# Altium schematic sheet 19-100M_Ethernet.SchDoc
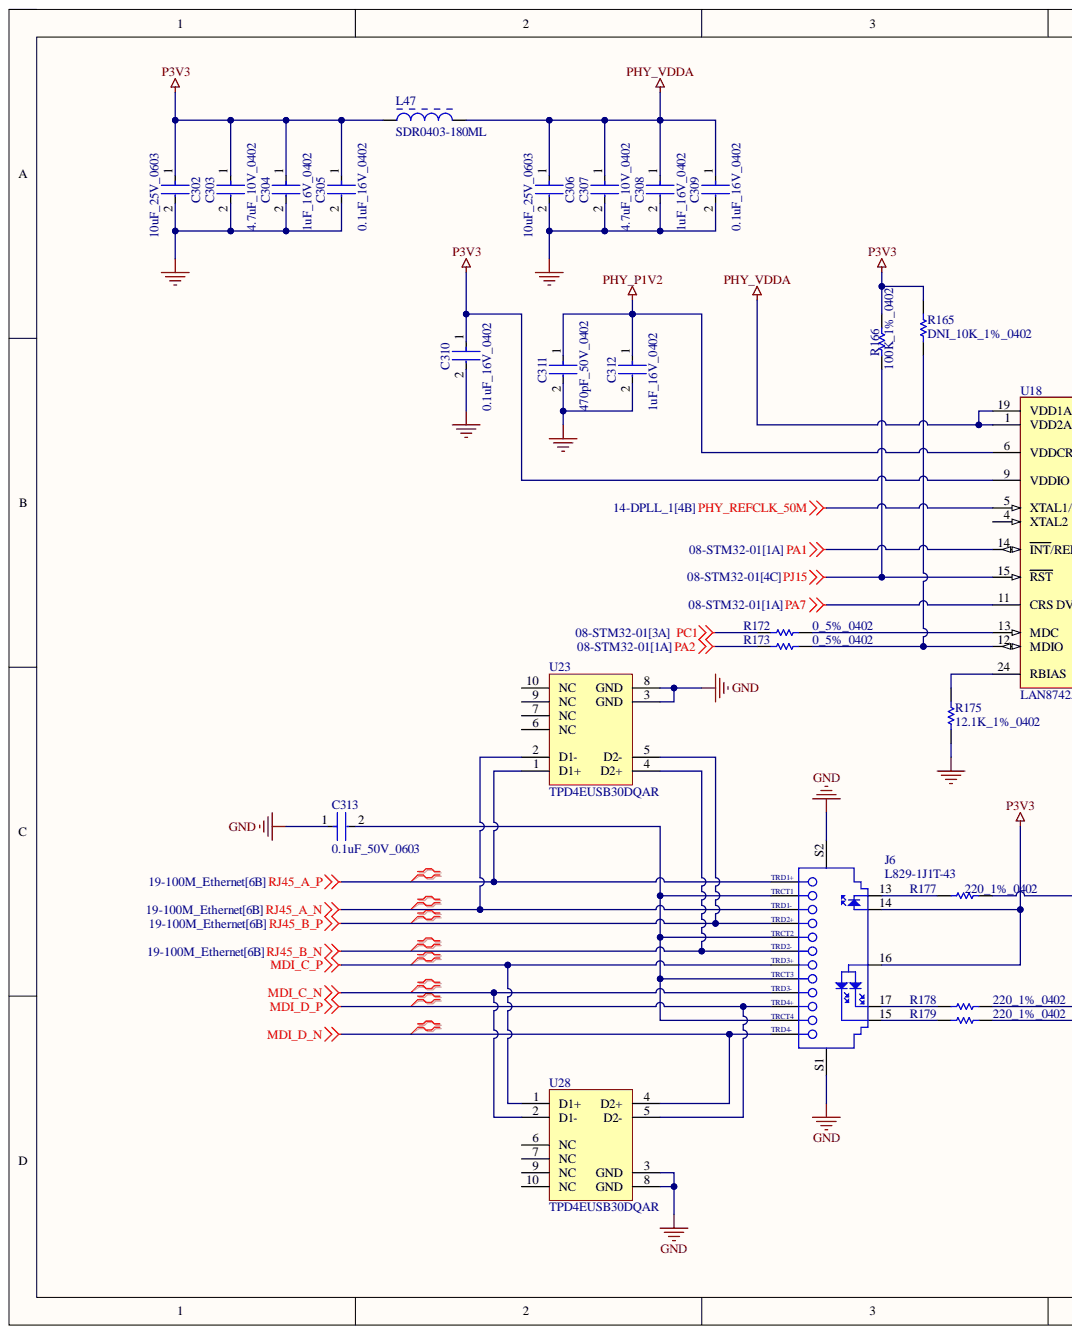
[diagram p0: 19-100M_Ethernet.SchDoc, left]
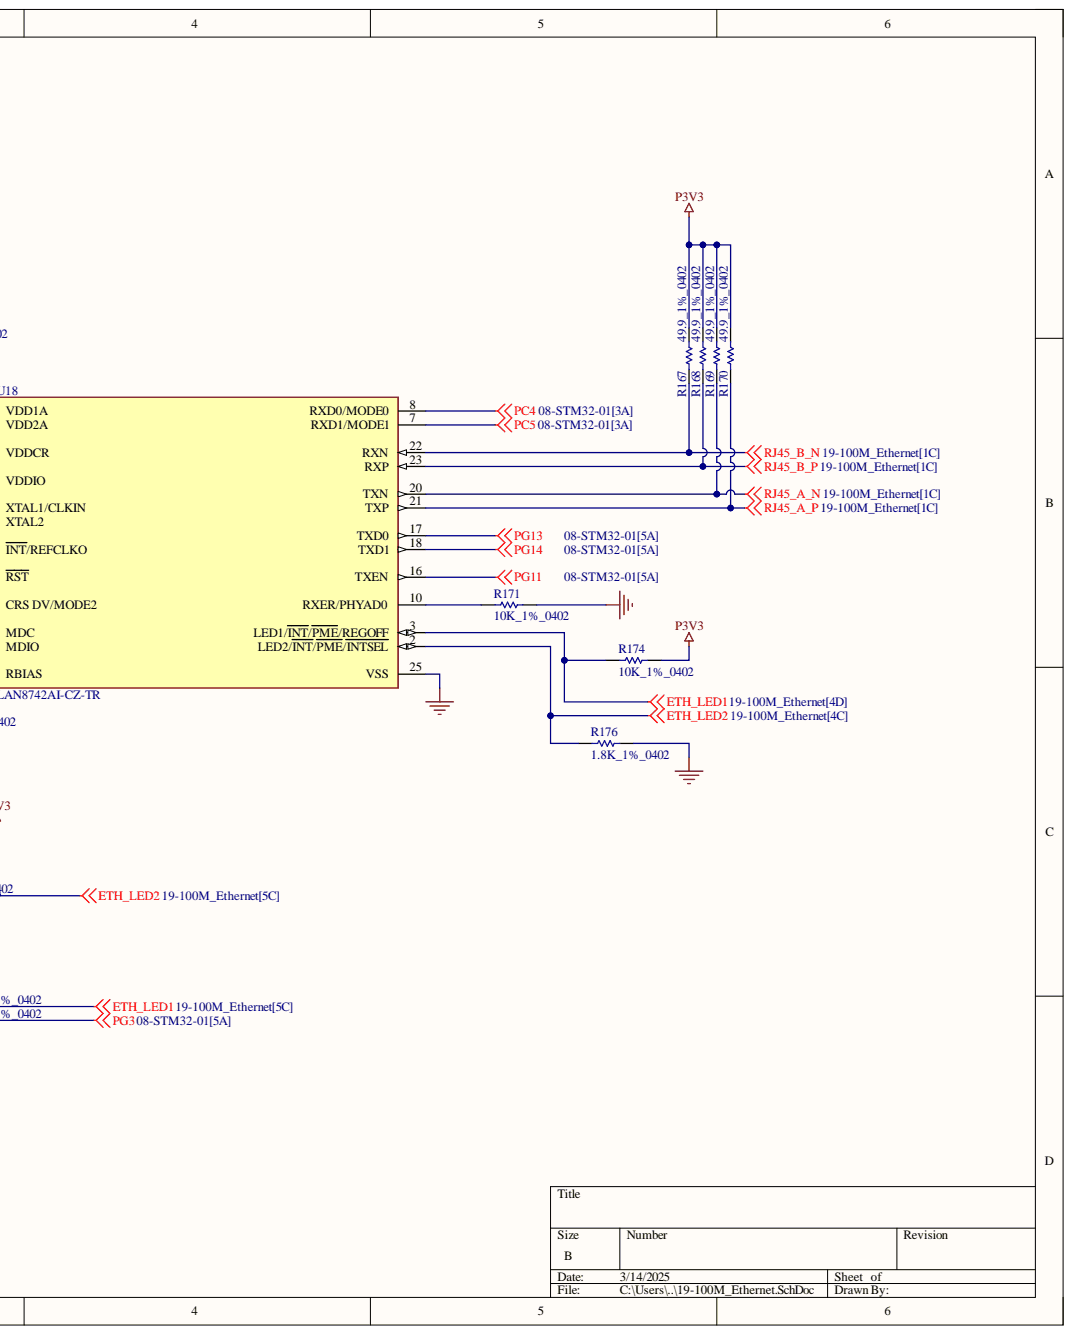
[diagram p1: 19-100M_Ethernet.SchDoc, right]

## Parts
C302  10uF_25V_0603  [FP-CL10-IPC_C]
C303  4.7uF_10V_0402  [FP-C1005-050-0_15-MFG]
C304  1uF_16V_0402  [FP-0402-L_1_0_0_05-W_0_5-IPC_A]
C305  0.1uF_16V_0402  [FP-C0402-BB-MFG]
C306  10uF_25V_0603  [FP-CL10-IPC_C]
C307  4.7uF_10V_0402  [FP-C1005-050-0_15-MFG]
C308  1uF_16V_0402  [FP-0402-L_1_0_0_05-W_0_5-IPC_A]
C309  0.1uF_16V_0402  [FP-C0402-BB-MFG]
C310  0.1uF_16V_0402  [FP-C0402-BB-MFG]
C311  470pF_50V_0402  [FP-GRM155-0_05-IPC_A]
C312  1uF_16V_0402  [FP-0402-L_1_0_0_05-W_0_5-IPC_A]
C313  0.1uF_50V_0603  [FP-C0603-CJ-MFG]
J6  L829-1J1T-43  [BELS-L829-1J1T-43_V]
L47  SDR0403-180ML  [BOUR-SDR0403-2_V]
R165  DNI_10K_1%_0402  [RESC1005X40X25NL10T10]  (no BOM)
R166  100K_1%_0402  [RESC1005X40X25ML05T10]
R167  49.9_1%_0402  [RESC1005X40X25NL10T10]
R168  49.9_1%_0402  [RESC1005X40X25NL10T10]
R169  49.9_1%_0402  [RESC1005X40X25NL10T10]
R170  49.9_1%_0402  [RESC1005X40X25NL10T10]
R171  10K_1%_0402  [RESC1005X40X25NL10T10]
R172  0_5%_0402  [RESC1005X40X25NL05T10]
R173  0_5%_0402  [RESC1005X40X25NL05T10]
R174  10K_1%_0402  [RESC1005X40X25NL10T10]
R175  12.1K_1%_0402  [RESC1005X40X25ML05T10]
R176  1.8K_1%_0402  [RESC1005X40X25LL05T05]
R177  220_1%_0402  [RESC1005X40X25LL10T10]
R178  220_1%_0402  [RESC1005X40X25LL10T10]
R179  220_1%_0402  [RESC1005X40X25LL10T10]
U18  LAN8742AI-CZ-TR  [FP-C04-143-MFG]
U23  TPD4EUSB30DQAR  [DQA0010A_V]
U28  TPD4EUSB30DQAR  [DQA0010A_V]

## Nets
ETH_LED1: R174.2 R178.2 U18.3(LED1/INT/PME/REGOFF)
ETH_LED2: R176.1 R177.2 U18.2(LED2/INT/PME/INTSEL)
GND: C302.2 C303.2 C304.2 C305.2 C306.2 C307.2 C308.2 C309.2 C310.2 C311.2 C312.2 C313.1 J6.S1 J6.S2 R171.1 R175.1 R176.2 U18.25(VSS) U23.3(GND) U23.8(GND) U28.3(GND) U28.8(GND)
MDI_C_N: J6.9 U28.2(D1-)
MDI_C_P: J6.7 U28.1(D1+)
MDI_D_N: J6.12 U28.4(D2+)
MDI_D_P: J6.10 U28.5(D2-)
P3V3: C302.1 C303.1 C304.1 C305.1 C310.1 J6.14 J6.16 L47.1 R165.1 R166.2 R167.1 R168.1 R169.1 R170.1 R174.1 U18.9(VDDIO)
PA1: U18.14(INT/REFCLKO)
PA2: R173.1
PA7: U18.11(CRS_DV/MODE2)
PC1: R172.1
PC4: U18.8(RXD0/MODE0)
PC5: U18.7(RXD1/MODE1)
PG3: R179.2
PG11: U18.16(TXEN)
PG13: U18.17(TXD0)
PG14: U18.18(TXD1)
PHY_P1V2: C311.1 C312.1 U18.6(VDDCR)
PHY_REFCLK_50M: U18.5(XTAL1/CLKIN)
PHY_VDDA: C306.1 C307.1 C308.1 C309.1 L47.2 U18.1(VDD2A) U18.19(VDD1A)
PJ15: R166.1 U18.15(RST)
RJ45_A_N: J6.3 R169.2 U18.20(TXN) U23.2(D1-)
RJ45_A_P: J6.1 R170.2 U18.21(TXP) U23.1(D1+)
RJ45_B_N: J6.6 R167.2 U18.22(RXN) U23.4(D2+)
RJ45_B_P: J6.4 R168.2 U18.23(RXP) U23.5(D2-)
NetC313_2: C313.2 J6.2 J6.5 J6.8 J6.11
NetJ6_13: J6.13 R177.1
NetJ6_15: J6.15 R179.1
NetJ6_17: J6.17 R178.1
NetR165_2: R165.2 R173.2 U18.12(MDIO)
NetR171_2: R171.2 U18.10(RXER/PHYAD0)
NetR172_2: R172.2 U18.13(MDC)
NetR175_2: R175.2 U18.24(RBIAS)

Not connected: U18 4(XTAL2); U23 6(NC) 7(NC) 9(NC) 10(NC); U28 6(NC) 7(NC) 9(NC) 10(NC)

## Library data of the parts
C302 C306 = CMP-13271-004224-1: Cap Ceramic 10uF 25V X5R ±20% Pad SMD 0603 +85°C T/R; Capacitance: 10uF; Case Code (Imperial): 0603; Case Code (Metric): 1608; Case/Package: 0603; Component Kind: Standard; Contact Plating: Tin; Dielectric: X5R; Lead Free: Lead Free; Length: 0.063inch; Library Name: Altium Content Vault; Max Operating Temperature: 85°C; Min Operating Temperature: -55°C; Mount: Surface Mount; Package Quantity: 1; Packaging: Tape and Reel; Termination: Wraparound; Thickness: 0.035inch; Tolerance: 20%; Voltage Rating: 25V; Width: 0.031inch
C303 C307 = CMP-2000-05997-2: CAP CER 4.7UF 10V X5R 0402; Dielectric Material: Ceramic; Tolerance: 10%; Capacitance: 4.7uF; Case Code (Imperial): 0402; Case Code (Metric): 1005; Case/Package: 0402; Component Kind: Standard; Dielectric: X5R; Lead Free: Lead Free; Length: 0.039inch; Library Name: Altium Content Vault; Max Operating Temperature: 85°C; Min Operating Temperature: -55°C; Mount: Surface Mount; Package Quantity: 10000; Packaging: Cut Tape; REACH SVHC: No SVHC; RoHS Compliant: Yes; Series: C; Thickness: 0.022inch; Voltage Rating: 10V; Voltage Rating (DC): 10V; Width: 0.02inch
C304 C308 C312 = CMP-14477-000104-2: None; Capacitance: 1uF; Dielectric: X5R; Tolerance: 10%; Voltage Rating: 16V; Case Code (Imperial): 0402; Case Code (Metric): 1005; Case/Package: 0402; Component Kind: Standard; Construction: Flat; Depth: 0.5mm; Halogen Free: Halogen Free; Height: 0.5mm; Length: 1mm; Library Name: Altium Content Vault; Max Operating Temperature: 85°C; Min Operating Temperature: -55°C; Mount: Surface Mount; Number of Pins: 2; Package Quantity: 1; Packaging: Tape and Reel; Radiation Hardening: No; RoHS Compliant: Yes; Series: M; Termination: SMD/SMT; Thickness: 0.022inch; Voltage Rating (DC): 16V; Width: 0.02inch
C305 C309 C310 = CMP-1034-02068-3: CAP CER 0.1UF 16V 5% X7R 0402; Manufacturer: Kemet; Manufacturer Part Number: C0402C104J4RACTU; Capacitance: 0.10 μF; Material: Ceramic; Temperature Coefficient: 15%; Tolerance: 0.05; Value: 0.10 μF; Automotive: No; Category: Cap; Component Kind: Standard; ComponentLink2Description: Datasheet; ComponentLink2URL: https://content.kemet.com/datasheets/KEM_C1002_X7R_SMD.pdf; Device Class L1: Passive Components; Device Class L2: Capacitors; Device Class L3: Ceramic Capacitors; Digikey Description: CAP CER 0.1UF 16V 5% X7R 0402; Height: 0.55mm; Ipc Land Pattern Name: CAPC10050X50; Lead Free: Yes; Library Name: Altium Content Vault; Mouser Description: CAP CER 0.1UF 16V X7R 0402; Octopart: https://octopart.com/c0402c104j4ractu-kemet-12573893; Package: C0402-BB; RoHS: TRUE; Temperature: -55°C to +125°C; Temperature Characteristic: X7R; Temperature Range High: +125°C; Temperature Range Low: -55°C; Voltage Rating: 16 V
C311 = CMP-2008-04369-2: Chip Multilayer Ceramic Capacitors for General Purpose, 0402, 470pF, C0G, 30ppm/°C, 5%, 50V; Insulation Resistance: 10GR; Capacitance: 470pF; Case Code (Imperial): 0402; Case Code (Metric): 1005; Case/Package: 0402; Component Kind: Standard; Depth: 0.5mm; Dielectric: C0G; Dielectric Material: Ceramic; Height: 0.5mm; Lead Free: Lead Free; Length: 1mm; Library Name: Altium Content Vault; Material: Ceramic; Max Operating Temperature: 125°C; Min Operating Temperature: -55°C; Mount: Surface Mount; Number of Pins: 2; Package Quantity: 1; Packaging: Tape and Reel; Radiation Hardening: No; REACH SVHC: No SVHC; Temperature Coefficient: 30ppm/°C; Termination: SMD/SMT; Thickness: 0.022inch; Tolerance: 5%; Voltage: 50V; Voltage Rating: 50V; Voltage Rating (DC): 50V; Width: 0.5mm
C313 = CMP-2006-03300-2: CAP CER 0.1UF 50V X7R 0603; Manufacturer: Kemet; Manufacturer Part Number: C0603X104K5RACTU; Capacitance: 100nF; Material: Ceramic; Temperature Coefficient: 15%; Tolerance: 10%; Value: 100nF; Automotive: No; Category: Cap; Component Kind: Standard; ComponentLink2Description: Datasheet; ComponentLink2URL: https://content.kemet.com/datasheets/KEM_C1013_X7R_FT-CAP_SMD.pdf; Device Class L1: Passive Components; Device Class L2: Capacitors; Device Class L3: Ceramic Capacitors; Digikey Description: CAP CER 0.1UF 50V X7R 0603; Height: 0.95mm; Ipc Land Pattern Name: CAPC16080X80; Lead Free: Yes; Library Name: Altium Content Vault; Mouser Description: Capacitor; Ceramic; Cap 0.1uF; Tol+10%; SMT; Flexible Termination; Vol-Rtg 50V; TR; Octopart: https://octopart.com/c0603x104k5ractu-kemet-9145062; Package: C0603-CJ; RoHS: TRUE; Temperature: -55°C to +125°C; Temperature Characteristic: X7R; Temperature Range High: +125°C; Temperature Range Low: -55°C; Voltage: 50V; Voltage Rating: 50V
J6 = CMP-1715-00001-1: Gigabit MagJack(R) Ultra Low Profile, 10/100/1000 Base-T, 19-Pin THD, RoHS, Tray; Case/Package: Metal; Component Kind: Standard; ComponentLink1Description: Manufacturer URL; ComponentLink1URL: http://belfuse.com/ethernet/; ComponentLink2Description: Datasheet; ComponentLink2URL: http://belfuse.com/pdfs/L829-1J1T-43.pdf; DatasheetVersion: Rev. G; Height: 11.3 mm; Length: 17.78 mm; Library Name: Altium Content Vault; Mount: Through Hole; Mounting Technology: Through Hole; Package Quantity: 60; PackageDescription: 19-Pin Through Hole Device, Body 23.94 x 17.58 mm, Pitch 2.03 mm; Packaging: Tray; PartNumber: L829-1J1T-43; Pins: 8; RoHSCompliant: True; Width: 24.13 mm
L47 = CMP-0904-00005-1: SDR0403 Series Power Inductor, 18 uF +/- 20 %, 0.34 Ohm, -40 to 125 degC, 2-Pin SMD, RoHS, Tape and Reel; Manufacturer: Bourns; I rms (A): 0.84 Max.; I sat (A): 1.3 Typ.; Inductance (uH): 18; Q: 25; RDC (Ohm): 0.34 Max.; SRF (MHz): 23 Min.; Test Frequency (MHz): 2.52; Tolerance (%): +/- 20; Component Kind: Standard; ComponentLink1Description: Manufacturer URL; ComponentLink1URL: http://www.bourns.com/; ComponentLink2Description: Datasheet; ComponentLink2URL: http://www.bourns.com/data/global/pdfs/sdr0403.pdf; DatasheetVersion: Feb-12; Library Name: Altium Content Vault; Mounting Technology: Surface Mount; PackageDescription: 3-Pin SMD, Dia 4.5 mm, Height 3.5 mm; PackageReference: SDR0403-2; Packing: Tape and Reel; PartNumber: SDR0403-180ML; RoHS: TRUE; Temperature Range: -40 to 125 degC
R165 R171 R174 = CMP-2002-04694-1: Manufacturer: Bourns; Part Number: CR0402-FX-1002GLF; Library Name: Altium Content Vault
  R171 R174: Component Kind: Standard
R166 = CMP-2002-07852-1: Manufacturer: Yageo; Part Number: RC0402FR-13100KL; Component Kind: Standard; Library Name: Altium Content Vault
R167-R170 = CMP-2002-04448-1: Manufacturer: KOA Speer; Part Number: RK73H1ETTP49R9F; Component Kind: Standard; Library Name: Altium Content Vault
R172 R173 = CMP-2002-06457-1: Manufacturer: Panasonic; Part Number: ERJ-U020R00X; Component Kind: Standard; Library Name: Altium Content Vault
R175 = CMP-2002-07375-1: Manufacturer: Yageo / Phycomp; Part Number: RC0402FR-0712K1L; Component Kind: Standard; Library Name: Altium Content Vault
R176 = CMP-2002-01128-1: Manufacturer: Panasonic; Part Number: ERJ2RKF1801X; Component Kind: Standard; Library Name: Altium Content Vault
R177-R179 = CMP-2002-03891-1: Manufacturer: KOA Speer; Part Number: RK73H1ETTP2200F; Component Kind: Standard; Library Name: Altium Content Vault
U18 = CMP-06281-000002-1: IC TRANSCEIVER FULL 1/1 24SQFN; Manufacturer: Microchip; Data Rate: 100Mbits/s; Interface: Ethernet,Other; Max Supply Voltage: 3.6V; Min Supply Voltage: 1.14V; Number Of Channels: 1; Simplex Duplex: Full Duplex; Standoff Height: 0mm; Ambient Temperature Range High: +85°C; Ambient Temperature Range Low: -40°C; Automotive: No; Category: IC; Component Kind: Standard; ComponentLink2Description: Datasheet; ComponentLink2URL: http://ww1.microchip.com/downloads/en/DeviceDoc/DS_LAN8742_00001989A.pdf; Device Class L1: Integrated Circuits (ICs); Device Class L2: Interface ICs; Device Class L3: Ethernet Interface ICs; Digikey Description: IC TRANSCEIVER FULL 1/1 24SQFN; Height: 1mm; Ipc Land Pattern Name: QFN50P400X400X90-24; Lead Free: Yes; Library Name: Altium Content Vault; Manufacturer Part Number: LAN8742AI-CZ-TR; Mouser Description: Small Footprint RMII 10/100 Ethernet Transceiver with flexPWR Technology; Octopart: https://octopart.com/lan8742ai-cz-tr-microchip-29030589; Package: C04-143; RoHS: TRUE; Temperature: -40°C to +85°C; Temperature Range High: +85°C; Temperature Range Low: -40°C
U23 U28 = CMP-0326-00027-3: ESD Solution for Super-Speed (6 GBPS) USB 3.0 Interface, 4 Channels, -40 to +85 degC, 10-pin SON (DQA), Green (RoHS & no Sb/Br); Manufacturer: Texas Instruments; 1394 / Firewire: TRUE; Breakdown Voltage(Min)(V): 8; Differential Capacitance(pF): 0.05; IO Capacitance(Typ)(pF): 0.8; IO Leakage Current(nA): 10; Component Kind: Standard; ComponentLink1Description: Manufacturer URL; ComponentLink1URL: http://www.ti.com/; ComponentLink2Description: Datasheet; ComponentLink2URL: http://www.ti.com/general/docs/lit/getliterature.tsp?genericPartNumber=TPD4EUSB30&fileType=pdf; ComponentLink3Description: URL Link1; ComponentLink3URL: SamplesURL:http://www.ti.com/product/tpd4eusb30#samples; DatasheetVersion: SLVSAC2C; Eco-Plan: Green (RoHS & no Sb/Br); HDMI: TRUE; IEC 61000-4-2 Air-Gap(+/- kV): 8; IEC 61000-4-2 Contact(+/- kV): 8; Library Name: Altium Content Vault; Mounting Technology: Surface Mount; PackageDescription: 10-Pin Plastic Small Outline No-Lead, 1 x 2.5 x 0.55 mm Body, 0.5 mm Pitch; PackageReference: DQA0010A; PackageVersion: revB, Sep-2009; PartNumber: TPD4EUSB30DQAR; Serial ATA / eSATA: TRUE; SubFamily: ESD Solution; USB Full Speed: TRUE; USB High Speed: TRUE; USB Super Speed: TRUE
